# Altium schematic sheet RCU Main.SchDoc("RCU Main")
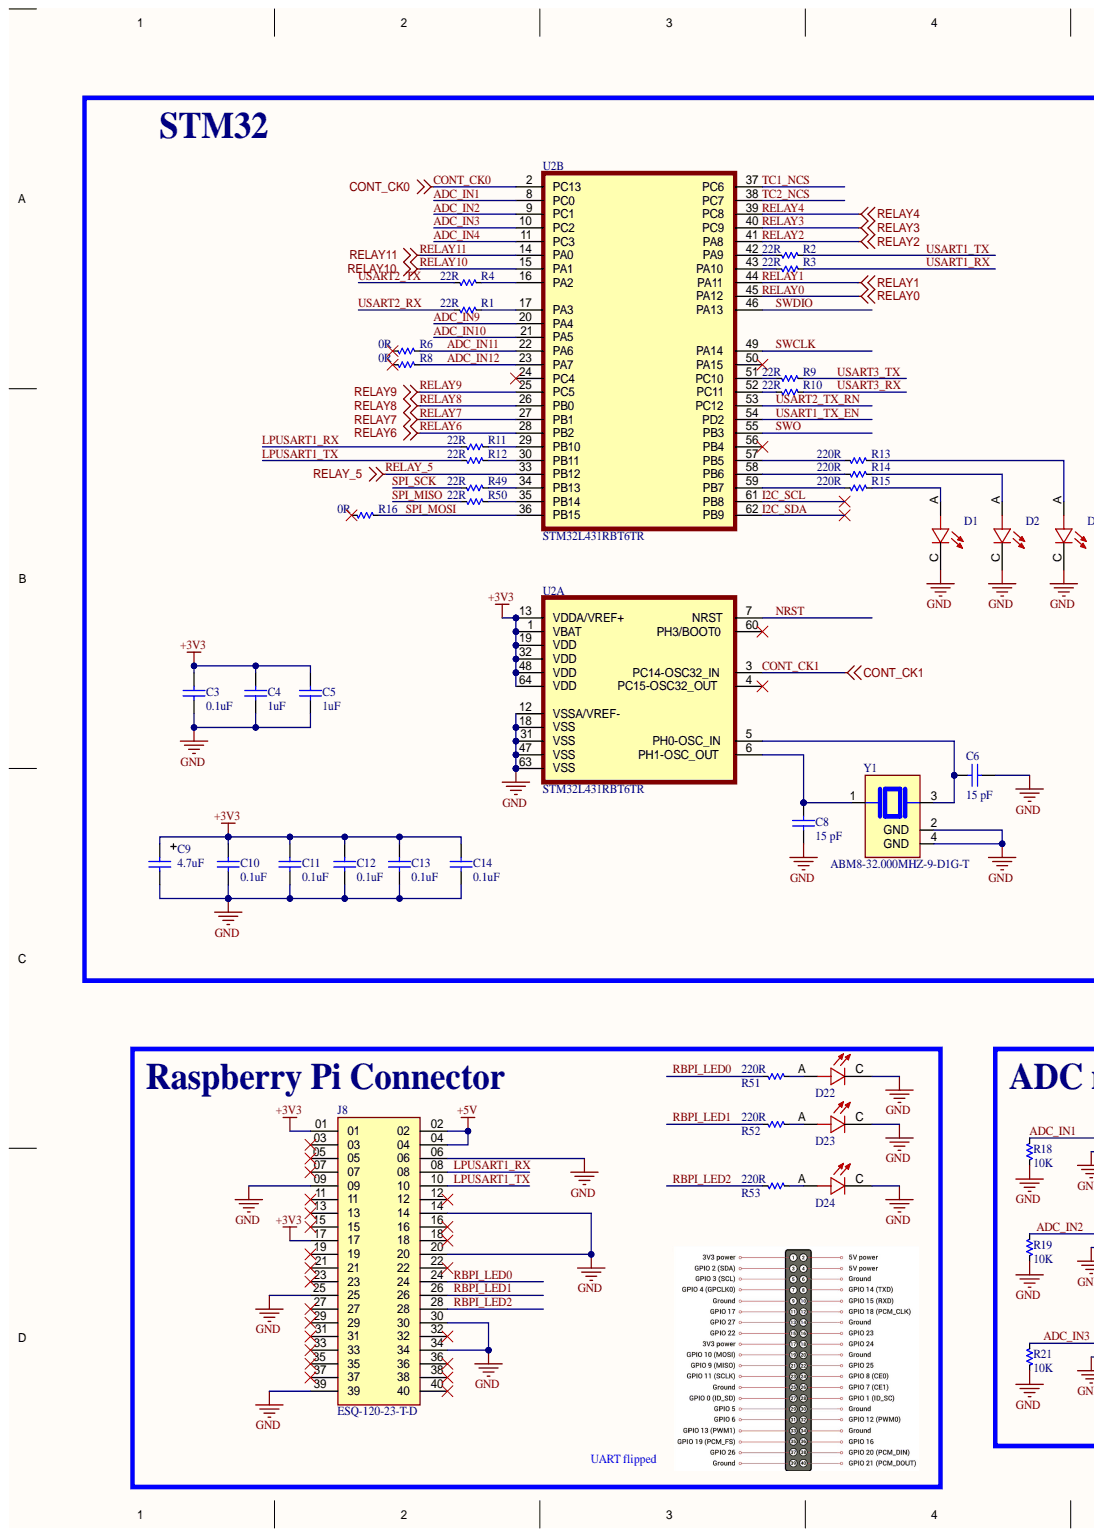
[diagram p0: RCU Main.SchDoc, left]
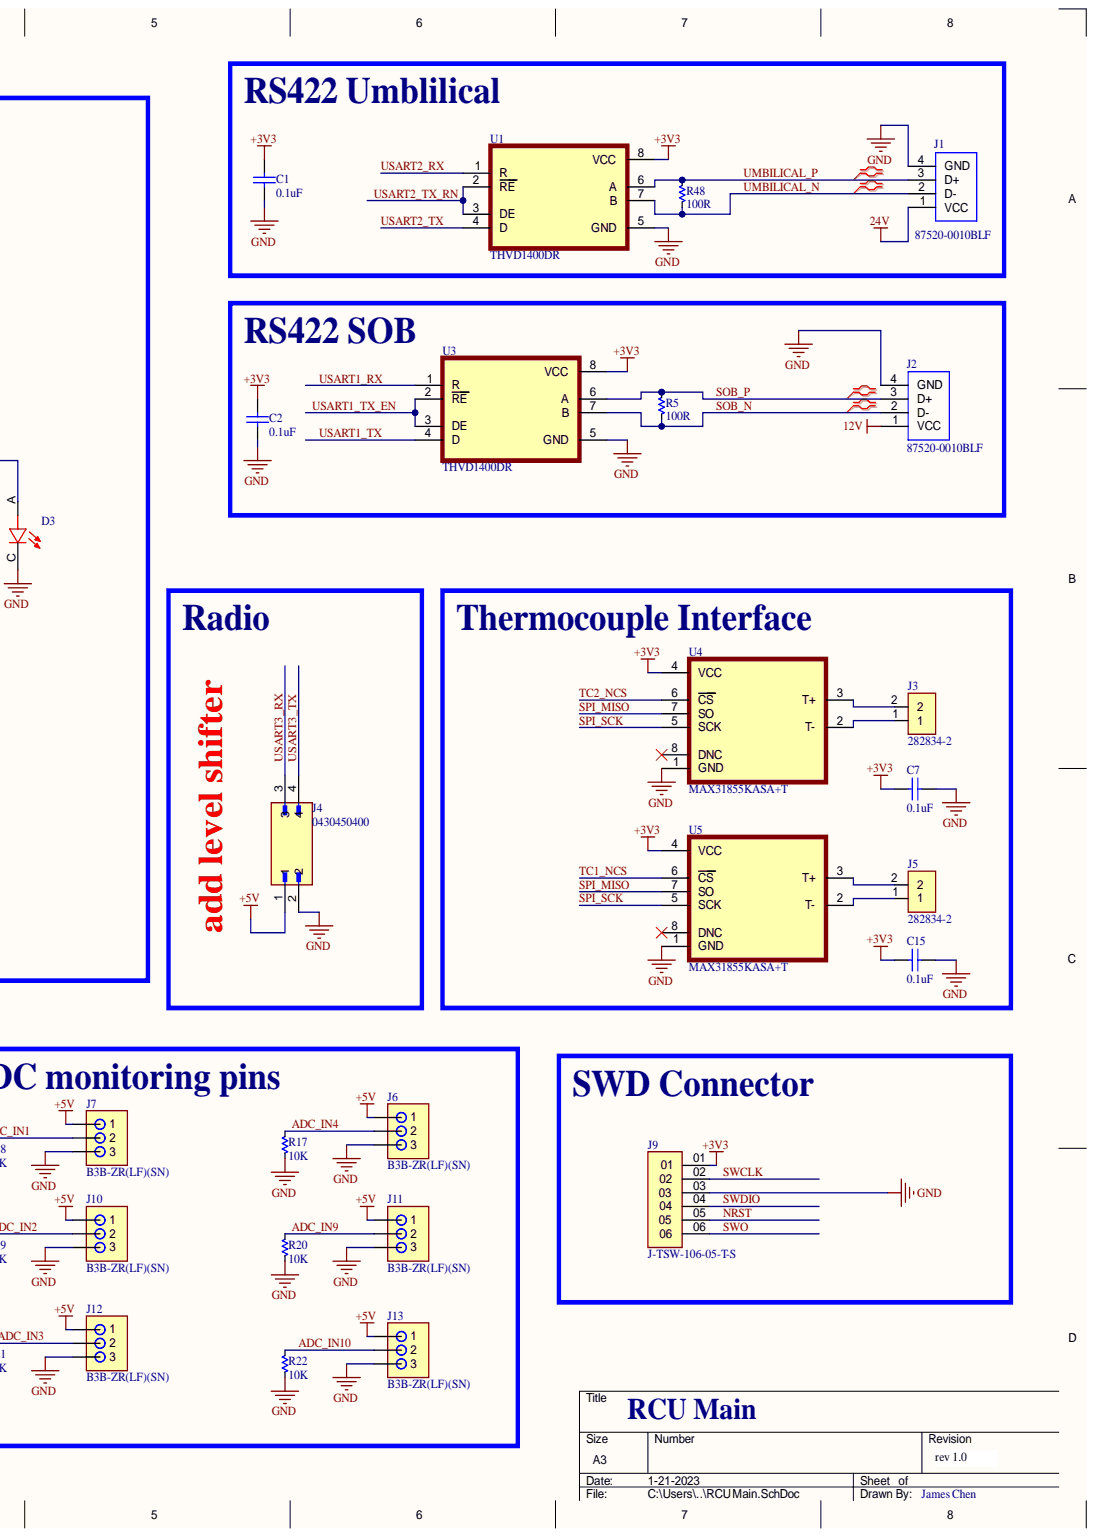
[diagram p1: RCU Main.SchDoc, right]

## Parts
C1  0.1uF  [0603]
C2  0.1uF  [0603]
C3  0.1uF  [0603]
C4  1uF  [0603]
C5  1uF  [0603]
C6  15 pF  [0402]
C7  0.1uF  [0603]
C8  15 pF  [0402]
C9  4.7uF  [CAP TANT 1206 (A) TCJ]
C10  0.1uF  [0603]
C11  0.1uF  [0603]
C12  0.1uF  [0603]
C13  0.1uF  [0603]
C14  0.1uF  [0603]
C15  0.1uF  [0603]
D1  D-SML-D12U1WT86  [D-SML-D12U1WT86]  (value not drawn)
D2  D-SML-D12U1WT86  [D-SML-D12U1WT86]  (value not drawn)
D3  D-SML-D12U1WT86  [D-SML-D12U1WT86]  (value not drawn)
D22  D-SML-D12U1WT86  [D-SML-D12U1WT86]  (value not drawn)
D23  D-SML-D12U1WT86  [D-SML-D12U1WT86]  (value not drawn)
D24  D-SML-D12U1WT86  [D-SML-D12U1WT86]  (value not drawn)
J1  87520-0010BLF  [J-87520-0010BLF]
J2  87520-0010BLF  [J-87520-0010BLF]
J3  282834-2  [J-282834-2]
J4  0430450400  [J-0430450400]
J5  282834-2  [J-282834-2]
J6  B3B-ZR(LF)(SN)  [J-B3B-ZR(LF)(SN)]
J7  B3B-ZR(LF)(SN)  [J-B3B-ZR(LF)(SN)]
J8  ESQ-120-23-T-D  [J-ESQ-120-23-T-D]
J9  J-TSW-106-05-T-S  [J-TSW-106-05-T-S]
J10  B3B-ZR(LF)(SN)  [J-B3B-ZR(LF)(SN)]
J11  B3B-ZR(LF)(SN)  [J-B3B-ZR(LF)(SN)]
J12  B3B-ZR(LF)(SN)  [J-B3B-ZR(LF)(SN)]
J13  B3B-ZR(LF)(SN)  [J-B3B-ZR(LF)(SN)]
R1  22R  [0603]
R2  22R  [0603]
R3  22R  [0603]
R4  22R  [0603]
R5  100R  [0603]
R6  0R  [0603]
R8  0R  [0603]
R9  22R  [0603]
R10  22R  [0603]
R11  22R  [0603]
R12  22R  [0603]
R13  220R  [0603]
R14  220R  [0603]
R15  220R  [0603]
R16  0R  [0603]
R17  10K  [0603]
R18  10K  [0603]
R19  10K  [0603]
R20  10K  [0603]
R21  10K  [0603]
R22  10K  [0603]
R48  100R  [0603]
R49  22R  [0603]
R50  22R  [0603]
R51  220R  [0603]
R52  220R  [0603]
R53  220R  [0603]
U1  THVD1400DR  [U-SN65176BDR]
U2  STM32L431RBT6TR  [U-STM32L431RBT6TR]  (parts A,B)
U3  THVD1400DR  [U-SN65176BDR]
U4  MAX31855KASA+T  [U-MAX31855KASA+T]
U5  MAX31855KASA+T  [U-MAX31855KASA+T]
Y1  ABM8-32.000MHZ-9-D1G-T  [Y-ASFL125000MHZLT]

## Nets
12V: J2.1(VCC)
24V: J1.1(VCC)
+3V3: C1.2 C2.2 C3.2 C4.2 C5.2 C7.2 C9.1 C10.2 C11.2 C12.2 C13.2 C14.2 C15.2 J8.01 J8.17 J9.01 U1.8(VCC) U2.1(VBAT) U2.13(VDDA/VREF+) U2.19(VDD) U2.32(VDD) U2.48(VDD) U2.64(VDD) U3.8(VCC) U4.4(VCC) U5.4(VCC)
+5V: J4.1 J6.1 J7.1 J8.02 J8.04 J10.1 J11.1 J12.1 J13.1
GND: C1.1 C2.1 C3.1 C4.1 C5.1 C6.1 C7.1 C8.1 C9.2 C10.1 C11.1 C12.1 C13.1 C14.1 C15.1 D1.C D2.C D3.C D22.C D23.C D24.C J1.4(GND) J2.4(GND) J4.2 J6.3 J7.3 J8.06 J8.09 J8.14 J8.20 J8.25 J8.30 J8.34 J8.39 J9.03 J10.3 J11.3 J12.3 J13.3 R17.1 R18.1 R19.1 R20.1 R21.1 R22.1 U1.5(GND) U2.12(VSSA/VREF-) U2.18(VSS) U2.31(VSS) U2.47(VSS) U2.63(VSS) U3.5(GND) U4.1(GND) U5.1(GND) Y1.2(GND) Y1.4(GND)
ADC_IN1: J7.2 R18.2 U2.8(PC0)
ADC_IN2: J10.2 R19.2 U2.9(PC1)
ADC_IN3: J12.2 R21.2 U2.10(PC2)
ADC_IN4: J6.2 R17.2 U2.11(PC3)
ADC_IN9: J11.2 R20.2 U2.20(PA4)
ADC_IN10: J13.2 R22.2 U2.21(PA5)
ADC_IN11: R6.2 U2.22(PA6)
ADC_IN12: R8.2 U2.23(PA7)
CONT_CK0: U2.2(PC13)
CONT_CK1: U2.3(PC14-OSC32_IN)
I2C_SCL: U2.61(PB8)
I2C_SDA: U2.62(PB9)
LPUSART1_RX: J8.08 R11.1
LPUSART1_TX: J8.10 R12.1
NRST: J9.05 U2.7(NRST)
RBPI_LED0: J8.24 R51.1
RBPI_LED1: J8.26 R52.1
RBPI_LED2: J8.28 R53.1
RELAY0: U2.45(PA12)
RELAY1: U2.44(PA11)
RELAY2: U2.41(PA8)
RELAY3: U2.40(PC9)
RELAY4: U2.39(PC8)
RELAY6: U2.28(PB2)
RELAY7: U2.27(PB1)
RELAY8: U2.26(PB0)
RELAY9: U2.25(PC5)
RELAY10: U2.15(PA1)
RELAY11: U2.14(PA0)
RELAY_5: U2.33(PB12)
SOB_N: J2.2(D-) R5.2 U3.7(B)
SOB_P: J2.3(D+) R5.1 U3.6(A)
SPI_MISO: R50.1 U4.7(SO) U5.7(SO)
SPI_MOSI: R16.2 U2.36(PB15)
SPI_SCK: R49.1 U4.5(SCK) U5.5(SCK)
SWCLK: J9.02 U2.49(PA14)
SWDIO: J9.04 U2.46(PA13)
SWO: J9.06 U2.55(PB3)
TC1_NCS: U2.37(PC6) U5.6(CS)
TC2_NCS: U2.38(PC7) U4.6(CS)
UMBILICAL_N: J1.2(D-) R48.2 U1.7(B)
UMBILICAL_P: J1.3(D+) R48.1 U1.6(A)
USART1_RX: R3.2 U3.1(R)
USART1_TX: R2.2 U3.4(D)
USART1_TX_EN: U2.54(PD2) U3.2(RE) U3.3(DE)
USART2_RX: R1.1 U1.1(R)
USART2_TX: R4.1 U1.4(D)
USART2_TX_RN: U1.2(RE) U1.3(DE) U2.53(PC12)
USART3_RX: J4.3 R10.2
USART3_TX: J4.4 R9.2
NetC6_2: C6.2 U2.5(PH0-OSC_IN) Y1.3
NetC8_2: C8.2 U2.6(PH1-OSC_OUT) Y1.1
NetD1_A: D1.A R15.2
NetD2_A: D2.A R14.2
NetD3_A: D3.A R13.2
NetD22_A: D22.A R51.2
NetD23_A: D23.A R52.2
NetD24_A: D24.A R53.2
NetJ3_1: J3.1 U4.2(T-)
NetJ3_2: J3.2 U4.3(T+)
NetJ5_1: J5.1 U5.2(T-)
NetJ5_2: J5.2 U5.3(T+)
NetR1_2: R1.2 U2.17(PA3)
NetR2_1: R2.1 U2.42(PA9)
NetR3_1: R3.1 U2.43(PA10)
NetR4_2: R4.2 U2.16(PA2)
NetR9_1: R9.1 U2.51(PC10)
NetR10_1: R10.1 U2.52(PC11)
NetR11_2: R11.2 U2.29(PB10)
NetR12_2: R12.2 U2.30(PB11)
NetR13_1: R13.1 U2.57(PB5)
NetR14_1: R14.1 U2.58(PB6)
NetR15_1: R15.1 U2.59(PB7)
NetR49_2: R49.2 U2.34(PB13)
NetR50_2: R50.2 U2.35(PB14)

Not connected: J8 03 05 07 11 12 13 15 16 18 19 21 22 23 27 29 31 32 33 35 36 37 38 40; R6 1; R8 1; R16 1; U2 4(PC15-OSC32_OUT) 24(PC4) 50(PA15) 56(PB4) 60(PH3/BOOT0); U4 8(DNC); U5 8(DNC)

## Library data of the parts
C1-C3 C7 C10-C15 = CC-104-K-50V-X7R-0603: CAP CER 0.1UF 50V X7R 0603; Manufacturer: Samsung Electro-Mechanics; Manufacturer Part #: CL10B104KB8NNWC; Component Kind: Standard; ComponentLink1description: Datasheet; ComponentLink1URL: https://ds.yuden.co.jp/TYCOMPAS/ut/detail?pn=TMK105BJ104KV-F%20%20&u=M; ComponentLink2description: Manufacturer Website; ComponentLink2URL: https://www.yuden.co.jp/or/; Distributor: Digikey; Distributor Part #: 1276-1935-1-ND; Part Description: CAP CER 0.1UF 50V X7R 0603; Value: 0.1uF; Library Name: RCU Board rev1.0.SchLib
C4 C5 = CC-105-K-25V-X7R-0603: CAP CER 1UF 25V X7R 0603; Manufacturer: Yageo; Manufacturer Part #: CC0603KRX7R8BB105; Component Kind: Standard; ComponentLink1Description: Datasheet; ComponentLink1URL: https://www.yageo.com/upload/media/product/productsearch/datasheet/mlcc/UPY-GPHC_X7R_6.3V-to-50V_18.pdf; ComponentLink2Description: Manufacture Website; ComponentLink2URL: https://www.yageo.com/en/Home; Distributor: Digikey; Distributor Part #: 311-1802-1-ND; Part Description: CAP CER 1UF 25V X7R 0603; SignalCraft Part #: CC-105-K-25V-X7R-0603; Value: 1uF; Library Name: RCU Board rev1.0.SchLib
C6 C8 = CC-159-J-50V-C0G-0402: 15 pF ±1% 50V Ceramic Capacitor C0G, NP0 0402 (1005 Metric); Manufacturer: YAGEO; Manufacturer Part #: CC0402FRNPO9BN150; Component Kind: Standard; ComponentLink1Description: Datasheet; ComponentLink2Description: Manufacture Website; Distributor: Digikey; Distributor Part #: 311-1642-1-ND; Part Description: 15 pF ±1% 50V Ceramic Capacitor C0G, NP0 0402 (1005 Metric); Value: 15 pF; Library Name: RCU Board rev1.0.SchLib
C9 = CT-474-M-20V-OTH-1206: 4.7 µF Molded Tantalum Capacitors 20 V 1206 (3216 Metric) 4Ohm; Manufacturer: AVX Corporation; Manufacturer Part #: TAJA475K020RNJ; Component Kind: Standard; ComponentLink1Description: Datasheet; ComponentLink2Description: Manufacturer Website; Distributor: Digikey; Distributor Part #: 478-1668-1-ND; Part Description: 4.7 µF Molded Tantalum Capacitors 20 V 1206 (3216 Metric) 4Ohm; Value: 4.7uF; Library Name: RCU Board rev1.0.SchLib
D1-D3 D22-D24 = D-SML-D12U1WT86: Red 620nm LED Indication - Discrete 2.2V 0603 _1608 Metric; Manufacturer: Rohm Semiconductor; Component Kind: Standard; Distributor: Digikey; Distributor Part #: SML-D12U1WT86CT-ND; Manufacturer Part #: SML-D12U1WT86; Library Name: RCU Board rev1.0.SchLib
J1 J2 = J-87520-0010BLF: CONN RCPT USB2.0 TYPEA 4POS R/A; Manufacturer: Amphenol ICC (FCI); Component Kind: Standard; Distributor: Digikey; Distributor Part #: 609-1045-ND; Manufacturer Part #: 87520-0010BLF; Library Name: RCU Board rev1.0.SchLib
J3 J5 = J-282834-2: TERM BLK 2P SIDE ENT 2.54MM PCB; Manufacturer: TE Connectivity AMP Connectors; Component Kind: Standard; Distributor: Digikey; Distributor Part #: A98333-ND; Manufacturer Part #: 282834-2; Library Name: RCU Board rev1.0.SchLib
J4 = J-0430450400: CONN HEADER R/A 4POS 3MM; Manufacturer: Molex; Component Kind: Standard; Distributor: Digikey; Distributor Part #: WM1814-ND; Manufacturer Part #: 0430450400; Library Name: RCU Board rev1.0.SchLib
J6 J7 J10-J13 = J-B3B-ZR(LF)(SN): CONN HEADER VERT 3POS 1.5MM; Manufacturer: JST Sales America Inc.; Component Kind: Standard; Distributor: Digikey; Distributor Part #: 455-1658-ND; Manufacturer Part #: B3B-ZR(LF)(SN); Library Name: RCU Board rev1.0.SchLib
J8 = J-ESQ-120-23-T-D: CONN SOCKET 40POS 0.1 TIN PCB; Manufacturer: Samtec Inc.; Component Kind: Standard; Distributor: Digikey; Distributor Part #: SAM9315-ND; Manufacturer Part #: ESQ-120-23-T-D; Library Name: RCU Board rev1.0.SchLib
J9 = J-TSW-106-05-T-S: CONN HEADER VERT 6POS 2.54MM; Distrbutor part #: SAM12323-ND; Manufacturer: Samtec; Distrbutor: None; Component Kind: Standard; Manufacturer Part #: TSW-106-05-T-S; Price: None; Library Name: RCU Board rev1.0.SchLib
R1-R4 R9-R12 R49 R50 = RT-22R0-F-1/16W-0603: 22 Ohms ±0.5% 0.063W, 1/16W Chip Resistor 0603 (1608 Metric) - Thin Film; Manufacturer: Susumu; Manufacturer Part #: RR0816Q-220-D; Component Kind: Standard; ComponentLink1description: Datasheet; ComponentLink1URL: https://www.vishay.com/docs/20035/dcrcwe3.pdf; ComponentLink2description: Manufacturer Website; ComponentLink2URL: https://www.vishay.com/; Distributor: Digikey; Distributor Part #: RR08Q22DCT-ND; Part Description: 22 Ohms ±0.5% 0.063W, 1/16W Chip Resistor 0603 (1608 Metric) - Thin Film; Value: 22R; Library Name: RCU Board rev1.0.SchLib
R5 R48 = RT-100R-F-1/16W-0603: RES SMD 100 OHM 0.5% 1/16W 0603; Manufacturer: Susumu; Manufacturer Part #: RR08P100DCT-ND; Component Kind: Standard; Distributor: Digikey; Distributor Part #: RR08P100DCT-ND; Part Description: RES SMD 100 OHM 0.5% 1/16W 0603; Value: 100R; Library Name: RCU Board rev1.0.SchLib
R6 R8 R16 = RT-0R00-F-1/10W-0603: 0 Ohms Jumper 0.1W, 1/10W Chip Resistor 0603 (1608 Metric) Automotive AEC-Q200 Thick Film; Manufacturer: Stackpole Electronics Inc; Manufacturer Part #: RMCF0603ZT0R00; Component Kind: Standard; ComponentLink1description: Datasheet; ComponentLink1URL: https://industrial.panasonic.com/ww/products/resistors/chip-resistors/general-purpose-chip-resistors/models/ERJ2GE0R00X; ComponentLink2description: Manufacturer Website; ComponentLink2URL: https://industrial.panasonic.com/ww/products/resistors/chip-resistors/general-purpose-chip-resistors/models/ERJ2GE0R00X; Distributor: Digikey; Distributor Part #: RMCF0603ZT0R00CT-ND; Part Description: 0 Ohms Jumper 0.1W, 1/10W Chip Resistor 0603 (1608 Metric) Automotive AEC-Q200 Thick Film; Value: 0R; Library Name: RCU Board rev1.0.SchLib
R13-R15 R51-R53 = RT-220R-F-1/16W-0603: 220 Ohms ±0.5% 0.063W, 1/16W Chip Resistor 0603 (1608 Metric) - Thin Film; Manufacturer: Susumu; Manufacturer Part #: RR0816P-221-D; Component Kind: Standard; Distributor: Digikey; Distributor Part #: RR08P220DCT-ND; Part Description: 220 Ohms ±0.5% 0.063W, 1/16W Chip Resistor 0603 (1608 Metric) - Thin Film; Value: 220R; Library Name: RCU Board rev1.0.SchLib
R17-R22 = RT-10K0-F-1/16W-0603: RES 10K OHM 1% 1/8W 0603; Manufacturer: Stackpole Electronics Inc; Manufacturer Part #: RNCP0603FTD10K0; Component Kind: Standard; ComponentLink1description: Datasheet; ComponentLink1URL: https://www.vishay.com/docs/20035/dcrcwe3.pdf; ComponentLink2description: Manufacturer Website; ComponentLink2URL: https://www.vishay.com/; Distributor: Digikey; Distributor Part #: RNCP0603FTD10K0CT-ND; Part Description: RES 10K OHM 1% 1/8W 0603; Value: 10K; Library Name: RCU Board rev1.0.SchLib
U1 U3 = U-THVD1400DR: IC TRANSCEIVER HALF 1/1 8SOIC; Manufacturer: Texas Instruments; Component Kind: Standard; Distributor: Digikey; Distributor Part #: 296-THVD1400DRCT-ND; Manufacturer Part #: THVD1400DR; Library Name: RCU Board rev1.0.SchLib
U2 = U-STM32L431RBT6TR: IC MCU 32BIT 128KB FLASH 64LQFP; Manufacturer: STMicroelectronics; Component Kind: Standard; Distributor: Digikey; Distributor Part #: 497-18832-1-ND; Manufacturer Part #: STM32L431RBT6TR; Library Name: RCU Board rev1.0.SchLib
U4 U5 = U-MAX31855KASA+T: IC CONV THERMOCOUPLE-DGTL SOIC; Manufacturer: Analog Devices Inc./Maxim Integrated; Component Kind: Standard; Distributor: Digikey; Distributor Part #: MAX31855KASA+TCT-ND; Manufacturer Part #: MAX31855KASA+T; Library Name: RCU Board rev1.0.SchLib
Y1 = Y-ABM8-32.000MHZ-9-D1G-T: CRYSTAL 32.0000MHZ 9PF SMD; Manufacturer: ABRACON; Component Kind: Standard; Distributor: Digikey; Distributor Part #: 535-15019-1-ND; Manufacturer Part #: ABM8-32.000MHZ-9-D1G-T; Library Name: RCU Board rev1.0.SchLib
